# Altium schematic sheet FPGA.SchDoc(FPGA)
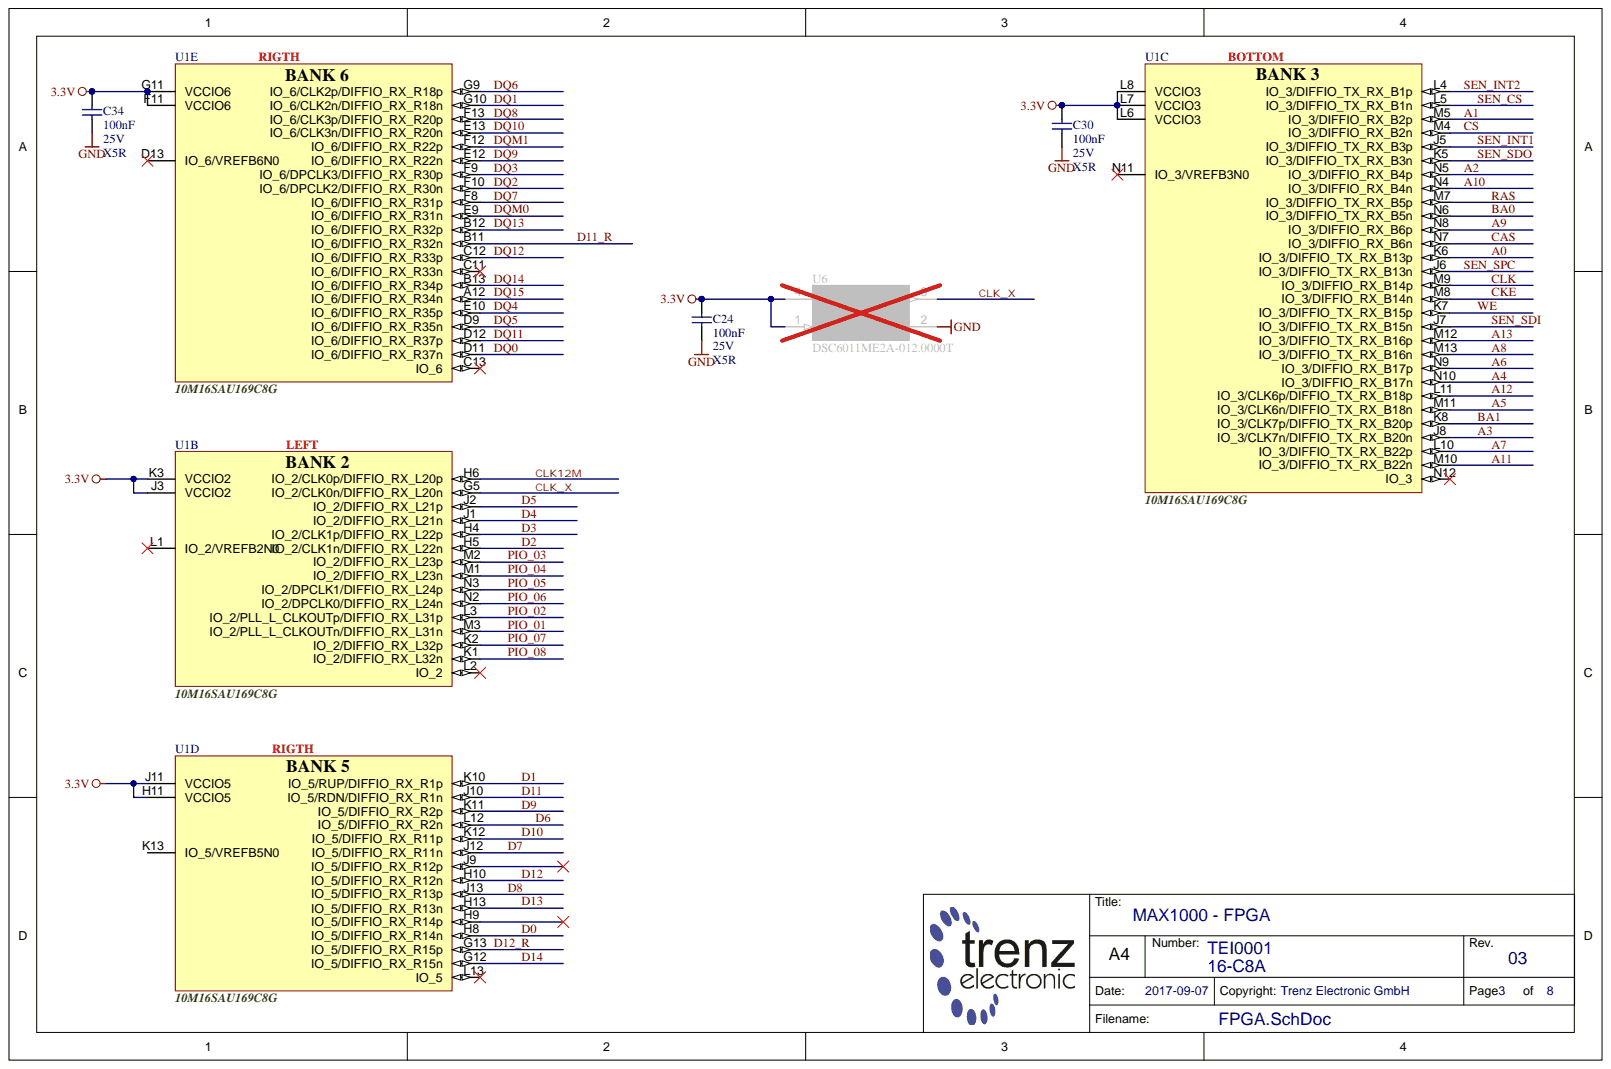
[diagram p0: FPGA.SchDoc]

## Parts
C24  100nF  Technology: X5R  Voltage: 25V  [CAPC1005X55N]
C30  100nF  Technology: X5R  Voltage: 25V  [CAPC1005X55N]
C34  100nF  Technology: X5R  Voltage: 25V  [CAPC1005X55N]
U1  10M08SAU169C8G  [BGA169C80P13X13_11X11X15]  (value not drawn)  (parts B,C,D,E)
U6  DSC6011ME2A-012.0000T  [2016]

## Nets
3.3V: C24.1 C30.1 C34.1 U1.F11(VCCIO6) U1.G11(VCCIO6) U1.H11(VCCIO5) U1.J3(VCCIO2) U1.J11(VCCIO5) U1.K3(VCCIO2) U1.L6(VCCIO3) U1.L7(VCCIO3) U1.L8(VCCIO3) U6.1(OE/ST/FS) U6.4(VDD)
GND: C24.2 C30.2 C34.2 U6.2(GND)
A0: U1.K6(IO_3/DIFFIO_TX_RX_B13p)
A1: U1.M5(IO_3/DIFFIO_RX_B2p)
A2: U1.N5(IO_3/DIFFIO_RX_B4p)
A3: U1.J8(IO_3/CLK7n/DIFFIO_TX_RX_B20n)
A4: U1.N10(IO_3/DIFFIO_RX_B17n)
A5: U1.M11(IO_3/CLK6n/DIFFIO_TX_RX_B18n)
A6: U1.N9(IO_3/DIFFIO_RX_B17p)
A7: U1.L10(IO_3/DIFFIO_TX_RX_B22p)
A8: U1.M13(IO_3/DIFFIO_TX_RX_B16n)
A9: U1.N8(IO_3/DIFFIO_RX_B6p)
A10: U1.N4(IO_3/DIFFIO_RX_B4n)
A11: U1.M10(IO_3/DIFFIO_TX_RX_B22n)
A12: U1.L11(IO_3/CLK6p/DIFFIO_TX_RX_B18p)
A13: U1.M12(IO_3/DIFFIO_TX_RX_B16p)
BA0: U1.N6(IO_3/DIFFIO_TX_RX_B5n)
BA1: U1.K8(IO_3/CLK7p/DIFFIO_TX_RX_B20p)
CAS: U1.N7(IO_3/DIFFIO_RX_B6n)
CKE: U1.M8(IO_3/DIFFIO_RX_B14n)
CLK: U1.M9(IO_3/DIFFIO_RX_B14p)
CLK12M: U1.H6(IO_2/CLK0p/DIFFIO_RX_L20p)
CLK_X: U1.G5(IO_2/CLK0n/DIFFIO_RX_L20n) U6.3(OUT)
CS: U1.M4(IO_3/DIFFIO_RX_B2n)
D0: U1.H8(IO_5/DIFFIO_RX_R14n)
D1: U1.K10(IO_5/RUP/DIFFIO_RX_R1p)
D2: U1.H5(IO_2/CLK1n/DIFFIO_RX_L22n)
D3: U1.H4(IO_2/CLK1p/DIFFIO_RX_L22p)
D4: U1.J1(IO_2/DIFFIO_RX_L21n)
D5: U1.J2(IO_2/DIFFIO_RX_L21p)
D6: U1.L12(IO_5/DIFFIO_RX_R2n)
D7: U1.J12(IO_5/DIFFIO_RX_R11n)
D8: U1.J13(IO_5/DIFFIO_RX_R13p)
D9: U1.K11(IO_5/DIFFIO_RX_R2p)
D10: U1.K12(IO_5/DIFFIO_RX_R11p)
D11: U1.J10(IO_5/RDN/DIFFIO_RX_R1n)
D11_R: U1.B11(IO_6/DIFFIO_RX_R32n)
D12: U1.H10(IO_5/DIFFIO_RX_R12n)
D12_R: U1.G13(IO_5/DIFFIO_RX_R15p)
D13: U1.H13(IO_5/DIFFIO_RX_R13n)
D14: U1.G12(IO_5/DIFFIO_RX_R15n)
DQ0: U1.D11(IO_6/DIFFIO_RX_R37n)
DQ1: U1.G10(IO_6/CLK2n/DIFFIO_RX_R18n)
DQ2: U1.F10(IO_6/DPCLK2/DIFFIO_RX_R30n)
DQ3: U1.F9(IO_6/DPCLK3/DIFFIO_RX_R30p)
DQ4: U1.E10(IO_6/DIFFIO_RX_R35p)
DQ5: U1.D9(IO_6/DIFFIO_RX_R35n)
DQ6: U1.G9(IO_6/CLK2p/DIFFIO_RX_R18p)
DQ7: U1.F8(IO_6/DIFFIO_RX_R31p)
DQ8: U1.F13(IO_6/CLK3p/DIFFIO_RX_R20p)
DQ9: U1.E12(IO_6/DIFFIO_RX_R22n)
DQ10: U1.E13(IO_6/CLK3n/DIFFIO_RX_R20n)
DQ11: U1.D12(IO_6/DIFFIO_RX_R37p)
DQ12: U1.C12(IO_6/DIFFIO_RX_R33p)
DQ13: U1.B12(IO_6/DIFFIO_RX_R32p)
DQ14: U1.B13(IO_6/DIFFIO_RX_R34p)
DQ15: U1.A12(IO_6/DIFFIO_RX_R34n)
DQM0: U1.E9(IO_6/DIFFIO_RX_R31n)
DQM1: U1.F12(IO_6/DIFFIO_RX_R22p)
PIO_01: U1.M3(IO_2/PLL_L_CLKOUTn/DIFFIO_RX_L31n)
PIO_02: U1.L3(IO_2/PLL_L_CLKOUTp/DIFFIO_RX_L31p)
PIO_03: U1.M2(IO_2/DIFFIO_RX_L23p)
PIO_04: U1.M1(IO_2/DIFFIO_RX_L23n)
PIO_05: U1.N3(IO_2/DPCLK1/DIFFIO_RX_L24p)
PIO_06: U1.N2(IO_2/DPCLK0/DIFFIO_RX_L24n)
PIO_07: U1.K2(IO_2/DIFFIO_RX_L32p)
PIO_08: U1.K1(IO_2/DIFFIO_RX_L32n)
RAS: U1.M7(IO_3/DIFFIO_TX_RX_B5p)
SEN_CS: U1.L5(IO_3/DIFFIO_TX_RX_B1n)
SEN_INT1: U1.J5(IO_3/DIFFIO_TX_RX_B3p)
SEN_INT2: U1.L4(IO_3/DIFFIO_TX_RX_B1p)
SEN_SDI: U1.J7(IO_3/DIFFIO_TX_RX_B15n)
SEN_SDO: U1.K5(IO_3/DIFFIO_TX_RX_B3n)
SEN_SPC: U1.J6(IO_3/DIFFIO_TX_RX_B13n)
WE: U1.K7(IO_3/DIFFIO_TX_RX_B15p)

Not connected: U1 C11(IO_6/DIFFIO_RX_R33n) C13(IO_6) D13(IO_6/VREFB6N0) H9(IO_5/DIFFIO_RX_R14p) J9(IO_5/DIFFIO_RX_R12p) K13(IO_5/VREFB5N0) L1(IO_2/VREFB2N0) L2(IO_2) L13(IO_5) N11(IO_3/VREFB3N0) N12(IO_3)

## Library data of the parts
C24 C30 C34 = 22472: KON 100nF 25V X5R SMD ±10%; Database Table Name: C_1005_0402; Mixed: SMT; Preferred: Yes; Value: 100n; AN: 22472; Case: 1005 (0402); Component Kind: Standard; Library Name: TE.DbLib
U1 = 27930: Database Table Name: PROG_LOGIC; Mixed: SMT; AN: 27930; Case: LFBGA169; Component Kind: Standard; Description: 10M08SAU169C8G; H-ART: 10M08SAU169C8G; Library Name: TE.DbLib; HelpURL: b:\AD\adlib\datasheet\ALTERA_MAX10\
U6 = 28002: Database Table Name: CLK; Mixed: SMT; Value: 12M; AN: 28002; Case: 2.0 mm x 1.6 mm; Component Kind: Standard; Description: DSC6011ME2A-012.0000T; H-ART: DSC6011ME2A-012.0000T; Library Name: TE.DbLib; HelpURL: b:\AD\adlib\datasheet\MICROCHIP_DSC60XX\
